# Altium schematic sheet Block Diagram.SchDoc
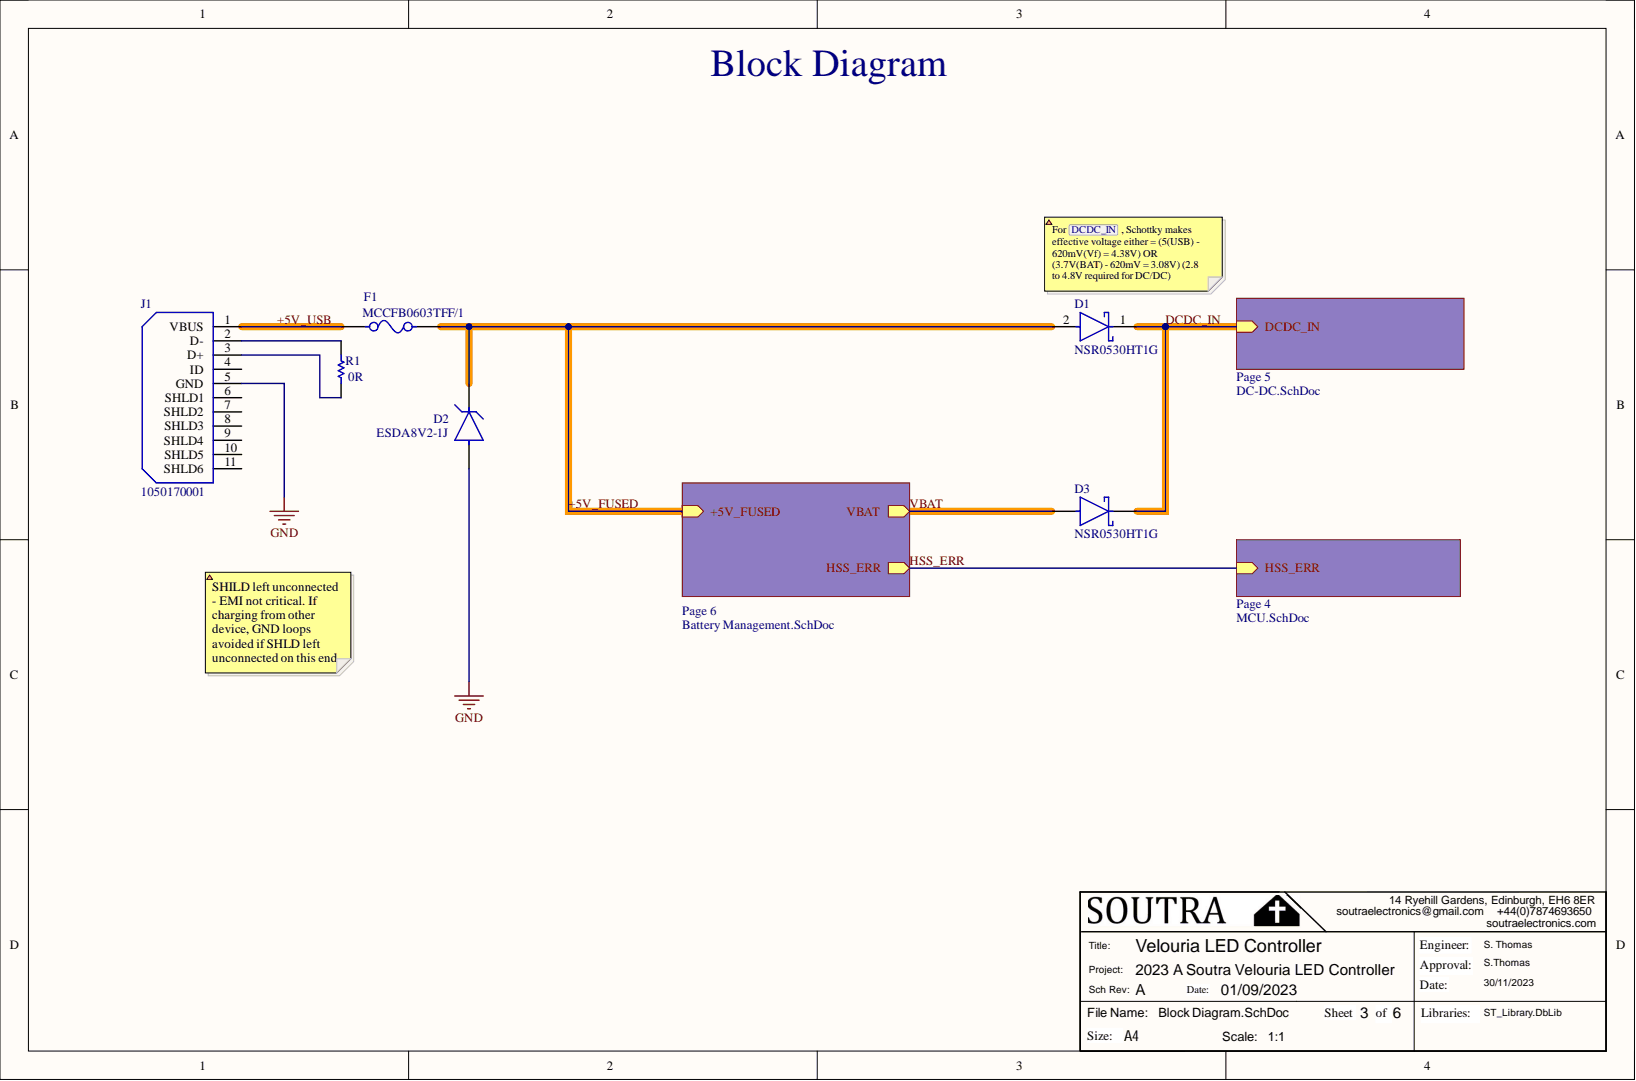
[diagram p0: Block Diagram.SchDoc]

## Parts
D1  NSR0530HT1G  [SODFL_250X100-2L]
D2  ESDA8V2-1J  [SODFL_250X117-2L]
D3  NSR0530HT1G  [SODFL_250X100-2L]
F1  MCCFB0603TFF/1  [FUSC_1608X06L]
J1  1050170001  [1050170001_USB-MICRO-B_V]
R1  value 0R  [RESC_0603_1608X06L]  (hidden comment: MC0063W06030R)

## Nets
GND: D2.2 J1.5(GND)
+5V_FUSED: D1.2 D2.1 F1.2
+5V_USB: F1.1 J1.1(VBUS)
DCDC_IN: D1.1 D3.1
VBAT: D3.2
NetJ1_2: J1.2(D-) R1.2
NetJ1_3: J1.3(D+) R1.1
NetJ1_4: J1.4(ID)
NetJ1_6: J1.6(SHLD1)
NetJ1_7: J1.7(SHLD2)
NetJ1_8: J1.8(SHLD3)
NetJ1_9: J1.9(SHLD4)
NetJ1_10: J1.10(SHLD5)
NetJ1_11: J1.11(SHLD6)
HSS_ERR:

## Library data of the parts
D1 D3 = NSR0530HT1G: Rectifier Diode, Schottky, 1 Phase, 1 Element, 0.5A, 30V V(RRM), Silicon; Alternative Part: PMEG3010BEA,115; Alternative Part Manufacturer: NEXPERIA; Manufacturer: ON Semiconductor; Max Output current: 500mA; Max Power Dissipation: 160mW; Number of Elements: 1; Package Body Material: Plastic; Alternative Part Supplier: Farnell; Alternative Part Supplier Part Number: 8737991; Category: Schottky Diodes; Component Kind: Standard; ComponentLink1Description: https://datasheet.ciiva.com:443/pdfs/VipMasterIC/IC/ONSM/ONSM-S-A0002239027/ONSM-S-A0002239027-1.pdf?src-supplier=IHS+Markit; ComponentLink1URL: https://datasheet.ciiva.com:443/pdfs/VipMasterIC/IC/ONSM/ONSM-S-A0002239027/ONSM-S-A0002239027-1.pdf?src-supplier=IHS+Markit; ComponentLink2Description: http://www.mouser.com/ds/2/308/NSR0530H-D-775571.pdf?src-supplier=Mouser; ComponentLink2URL: http://www.mouser.com/ds/2/308/NSR0530H-D-775571.pdf?src-supplier=Mouser; ComponentLink3Description: http://www.farnell.com/datasheets/2354965.pdf?src-supplier=Farnell; ComponentLink3URL: http://www.farnell.com/datasheets/2354965.pdf?src-supplier=Farnell; ComponentLink4Description: https://datasheet.octopart.com/NSR0530HT1G-ON-Semiconductor-datasheet-94100651.pdf?src-supplier=Newark; ComponentLink4URL: https://datasheet.octopart.com/NSR0530HT1G-ON-Semiconductor-datasheet-94100651.pdf?src-supplier=Newark; ComponentLink5Description: http://www.farnell.com/datasheets/2354965.pdf?src-supplier=Element14; ComponentLink5URL: http://www.farnell.com/datasheets/2354965.pdf?src-supplier=Element14; ComponentLink6Description: http://www.onsemi.com/pub/Collateral/NSR0530H-D.PDF?src-supplier=Avnet; ComponentLink6URL: http://www.onsemi.com/pub/Collateral/NSR0530H-D.PDF?src-supplier=Avnet; ComponentLink7Description: http://www.onsemi.com/pub/Collateral/NSR0530H-D.PDF?src-supplier=Digi-Key; ComponentLink7URL: http://www.onsemi.com/pub/Collateral/NSR0530H-D.PDF?src-supplier=Digi-Key; ComponentLink8Description: http://www.farnell.com/datasheets/2354965.pdf?src-supplier=Newark; ComponentLink8URL: http://www.farnell.com/datasheets/2354965.pdf?src-supplier=Newark; Diode Element Material: SILICON; Diode Type: RECTIFIER; JESD-30 Code: R-PDSO-G2; JESD-609 Code: e3; Library Name: ST_Library.IntLib; Manufacturer Part Number: NSR0530HT1G; Max Operating Temperature: 150°C; Min Operating Temperature: -55°C; Moisture Sensitivity Level: 1; Package Shape: Rectangular; Package Style: SMALL OUTLINEMeter; Pins: 2; Pricing: 5=0.159, 10=0.117, 100=0.0654; Pricing 1: 1=0.16, 10=0.11, 100=0.059; Reach SVHC Compliant: Yes; Rep Pk Reverse Voltage-Max: 30V; RoHS: Yes; RoHS Compliant: Yes; Stock: 24000; Supplier: Farnell; Supplier 1: Digikey; Supplier Part Number: 2508350; Supplier Part Number 1: NSR0530HT1GOSCT-ND; Surface Mount: Yes; Technology: SCHOTTKY; Terminal Finish: Tin; Terminal Form: Gull Wing; Terminal Position: DUAL
D2 = ESDA8V2-1J: Trans Voltage Suppressor Diode; Manufacturer: STMicroelectronics; Category: TVS Diodes; Component Kind: Standard; ComponentLink1Description: https://datasheet.ciiva.com:443/pdfs/VipMasterIC/IC/SGST/SGSTS35781/SGSTS35781-1.pdf?src-supplier=IHS+Markit; ComponentLink1URL: https://datasheet.ciiva.com:443/pdfs/VipMasterIC/IC/SGST/SGSTS35781/SGSTS35781-1.pdf?src-supplier=IHS+Markit; ComponentLink2Description: https://datasheet.ciiva.com/4510/cd00233088-241625-4510830.pdf?src-supplier=Mouser; ComponentLink2URL: https://datasheet.ciiva.com/4510/cd00233088-241625-4510830.pdf?src-supplier=Mouser; ComponentLink3Description: https://datasheet.ciiva.com/41444/001183817-da-01-en-transil-eos-esd-esda8v2-1j-sod-323-stm-41444438.pdf?src-supplier=Conrad; ComponentLink3URL: https://datasheet.ciiva.com/41444/001183817-da-01-en-transil-eos-esd-esda8v2-1j-sod-323-stm-41444438.pdf?src-supplier=Conrad; ComponentLink4Description: https://datasheet.ciiva.com/339/cd00233088-339344.pdf?src-supplier=Digi-Key; ComponentLink4URL: https://datasheet.ciiva.com/339/cd00233088-339344.pdf?src-supplier=Digi-Key; Diode Type: TRANS VOLTAGE SUPPRESSOR; JESD-609 Code: e3; Library Name: ST_Library.IntLib; Manufacturer Part Number: ESDA8V2-1J; Moisture Sensitivity Level: 1; Pricing: 5=0.0848, 10=0.0807, 100=0.0765; Pricing 1: 100=0.148; Reach SVHC Compliant: Yes; RoHS: Yes; RoHS Compliant: Yes; Stock: 124228; Supplier: Farnell; Supplier 1: Rs; Supplier Part Number: 2353667; Supplier Part Number 1: 9172719; Terminal Finish: Tin
F1 = MCCFB0603TFF/1: Fuse, Surface Mount, 1 A, Fast Acting, 32 VDC, 0603 [1608 Metric]; Alternative Part: MP001585; Alternative Part Manufacturer: Multicomp Pro; Manufacturer: Multicomp; Alternative Part Supplier: Farnell; Alternative Part Supplier Part Number: 3285087; Component Kind: Standard; Library Name: ST_Library.IntLib; Manufacturer Part Number: MCCFB0603TFF/1; Pricing: 1=0.027 (GBP); RoHS: No; Stock: 12700; Supplier: Farnell; Supplier Part Number: 2849996
J1 = 1050170001: Telecom and Datacom Connector, 5 Contact(s), Female, Right Angle, Surface Mount Terminal, Detent, Receptacle; Manufacturer: Molex; Manufacturer Part Number: 105017-0001; Component Kind: Standard; Library Name: ST_Library.IntLib; Pricing: 1=0.97; Pricing 1: 5=0.754, 75=0.67; Supplier: Farnell; Supplier 1: Rs; Supplier Part Number: 3500663; Supplier Part Number 1: 8486764
R1 = MC0063W06030R: Fixed Resistor, Metal Glaze/thick Film, 0.063W, 0ohm, 1% +/-Tol, Surface Mount, 0603; Alternative Part: MCWR06X000 PTL; Alternative Part Manufacturer: Multicomp Pro; Manufacturer: Multicomp; Manufacturer Series: 0603; Package Height: 0.45mm; Package Length: 1.6mm; Package Width: 0.8mm; Power: 63mW; Rated Temperature: 70°C; Alternative Part Supplier: Farnell; Alternative Part Supplier Part Number: 2447743; Case/Package: 0603; Category: Chip SMD Resistors; Component Kind: Standard; ComponentLink1Description: https://datasheet.ciiva.com:443/pdfs/VipMasterIC/IC/SCMP/SCMP-S-A0002523795/SCMP-S-A0002523795-1.pdf?src-supplier=IHS+Markit; ComponentLink1URL: https://datasheet.ciiva.com:443/pdfs/VipMasterIC/IC/SCMP/SCMP-S-A0002523795/SCMP-S-A0002523795-1.pdf?src-supplier=IHS+Markit; ComponentLink2Description: https://datasheet.ciiva.com/7776/1739504-7776679.pdf?src-supplier=Element14; ComponentLink2URL: https://datasheet.ciiva.com/7776/1739504-7776679.pdf?src-supplier=Element14; ComponentLink3Description: https://datasheet.ciiva.com/7776/1739504-7776680.pdf?src-supplier=Element14; ComponentLink3URL: https://datasheet.ciiva.com/7776/1739504-7776680.pdf?src-supplier=Element14; Construction: Chip; Library Name: ST_Library.IntLib; Manufacturer Part Number: MC0063W06030R; Max Operating Temperature: 155°C; Min Operating Temperature: -55°C; Mounting Technology: Surface Mount; Package Shape: Rectangular; Package Style: SMTMeter; Packaging: Tape and Reel; Pins: 2; Pricing: 10=0.007, 100=0.006; Resistor Type: FIXED RESISTOR; RoHS: Yes; RoHS Compliant: Yes; Series: MC(0603)THICK-FILM; Stock: 332297; Supplier: Farnell; Supplier Part Number: 9331662; Technology: METAL GLAZE/THICK FILM; Termination: Wraparound; Tolerance: 1%
